# Altium schematic sheet IO.SchDoc(IO)
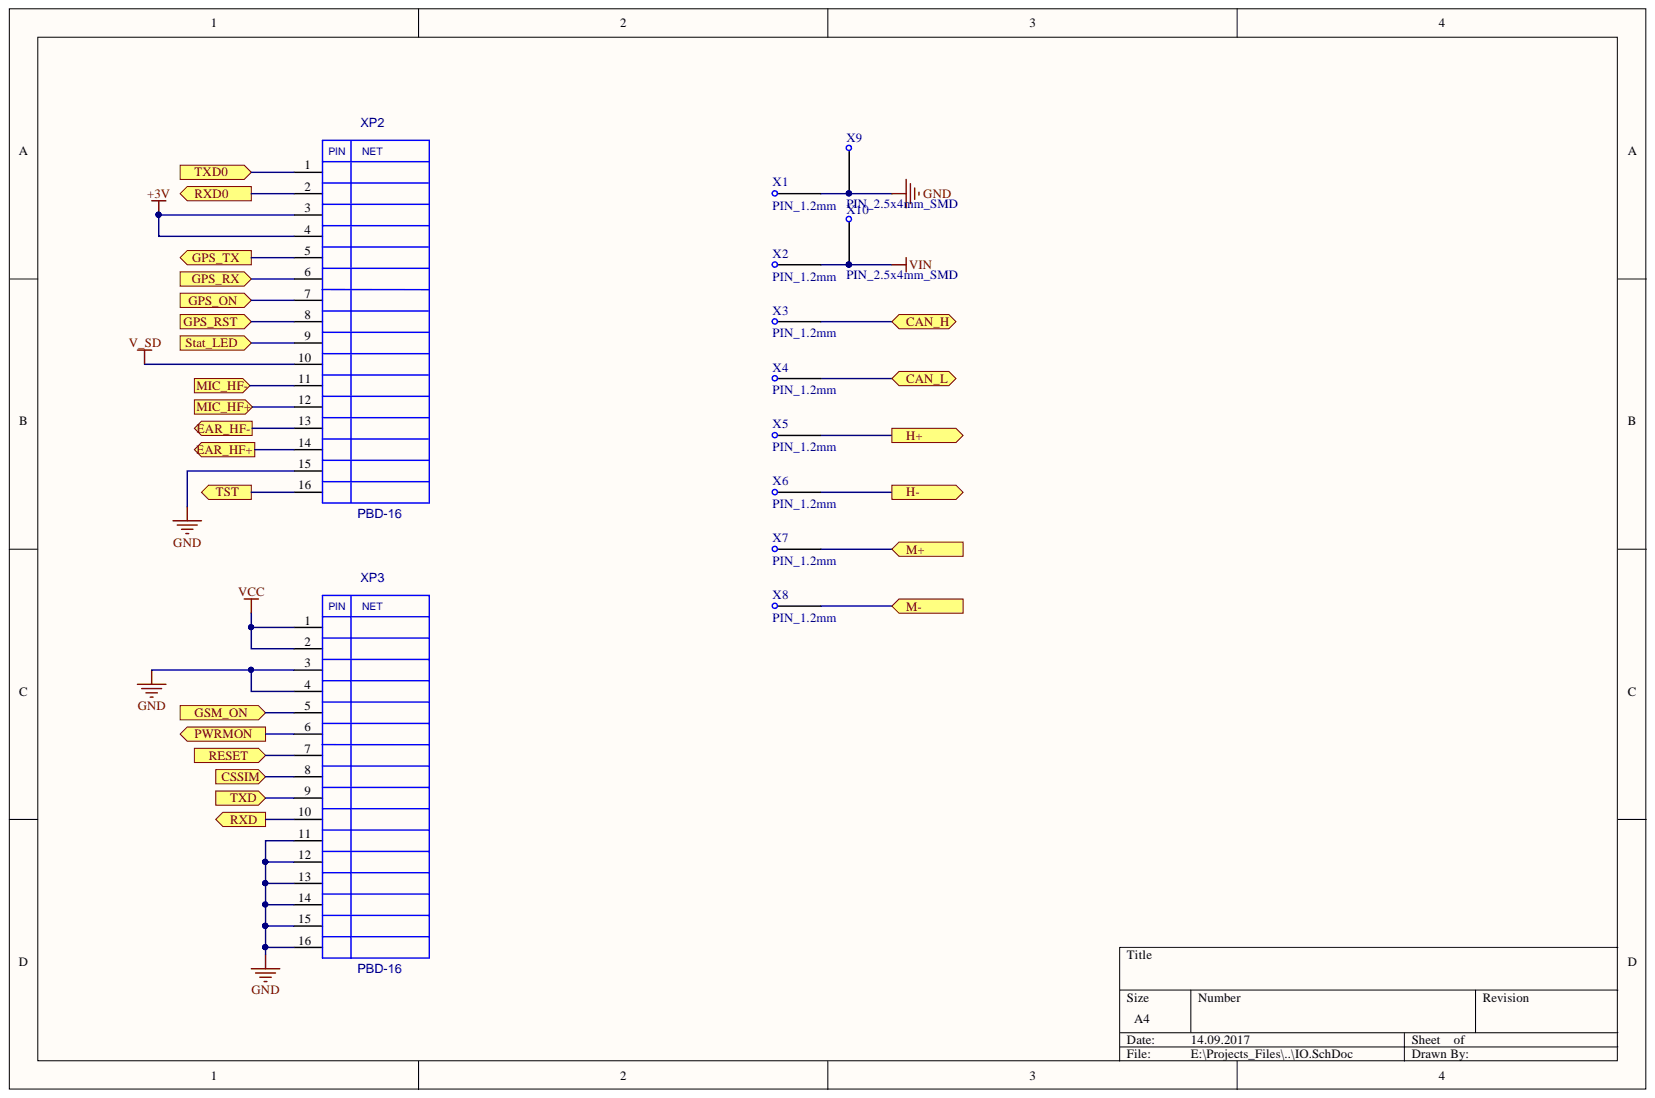
[diagram p0: IO.SchDoc]

## Parts
X1  PIN_1.2mm  [PIN_1.2mm]
X2  PIN_1.2mm  [PIN_1.2mm]
X3  PIN_1.2mm  [PIN_1.2mm]
X4  PIN_1.2mm  [PIN_1.2mm]
X5  PIN_1.2mm  [PIN_1.2mm]
X6  PIN_1.2mm  [PIN_1.2mm]
X7  PIN_1.2mm  [PIN_1.2mm]
X8  PIN_1.2mm  [PIN_1.2mm]
X9  PIN_2.5x4mm_SMD  [PIN_2.5x4mm_SMD]
X10  PIN_2.5x4mm_SMD  [PIN_2.5x4mm_SMD]
XP2  PBD-16  [PBD16]
XP3  PBD-16  [PBD16]

## Ports
CAN_H, CAN_L, CSSIM, EAR_HF+, EAR_HF-, GPS_ON, GPS_RST, GPS_RX, GPS_TX, GSM_ON, H+, H-, M+, M-, MIC_HF+, MIC_HF-, PWRMON, RESET, RXD, RXD0, Stat_LED, TST, TXD, TXD0

## Nets
+3V: XP2.3 XP2.4
GND: X1.1 X9.1 XP2.15 XP3.3 XP3.4 XP3.11 XP3.12 XP3.13 XP3.14 XP3.15 XP3.16
V_SD: XP2.10
VCC: XP3.1 XP3.2
VIN: X2.1 X10.1
CAN_H: X3.1 ; port CAN_H
CAN_L: X4.1 ; port CAN_L
CSSIM: XP3.8 ; port CSSIM
GPS_ON: XP2.7 ; port GPS_ON
GPS_RST: XP2.8 ; port GPS_RST
GPS_RX: XP2.6 ; port GPS_RX
GPS_TX: XP2.5 ; port GPS_TX
GSM_ON: XP3.5 ; port GSM_ON
PWRMON: XP3.6 ; port PWRMON
RESET: XP3.7 ; port RESET
RXD: XP3.10 ; port RXD
RXD0: XP2.2 ; port RXD0
Stat_LED: XP2.9 ; port Stat_LED
TST: XP2.16 ; port TST
TXD: XP3.9 ; port TXD
TXD0: XP2.1 ; port TXD0
NetX5_1: X5.1
NetX6_1: X6.1
NetX7_1: X7.1
NetX8_1: X8.1
NetXP2_11: XP2.11
NetXP2_12: XP2.12
NetXP2_13: XP2.13
NetXP2_14: XP2.14

## Library data of the parts
X1-X8 = PIN_1.2mm: Component Kind: Standard; Library Name: gprs_modem_2.SchLib
X9 X10 = PIN_2.5x4mm_SMD: Component Kind: Standard; Library Name: gprs_modem_2.SchLib
XP2 XP3 = PBD-16: Description: PBD-16; Component Kind: Standard; Library Name: gprs_modem.SchLib
